# Altium schematic sheet LOAD_SWITCHES.SchDoc
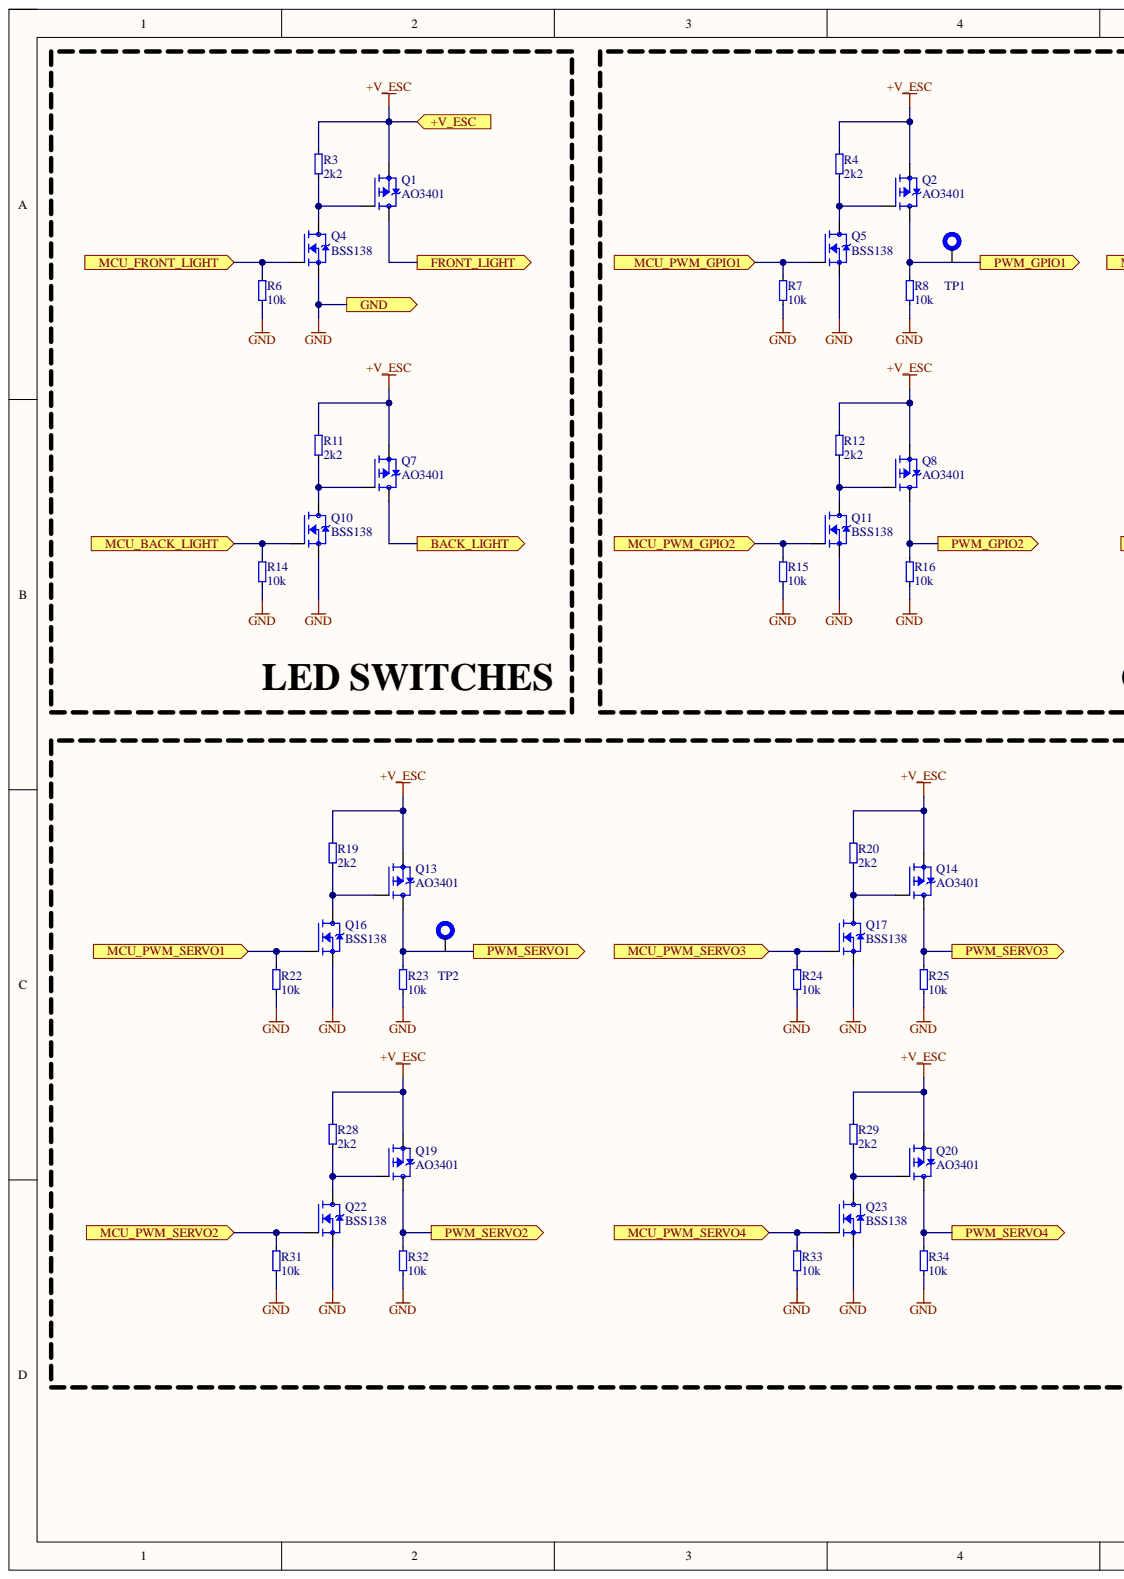
[diagram p0: LOAD_SWITCHES.SchDoc, left]
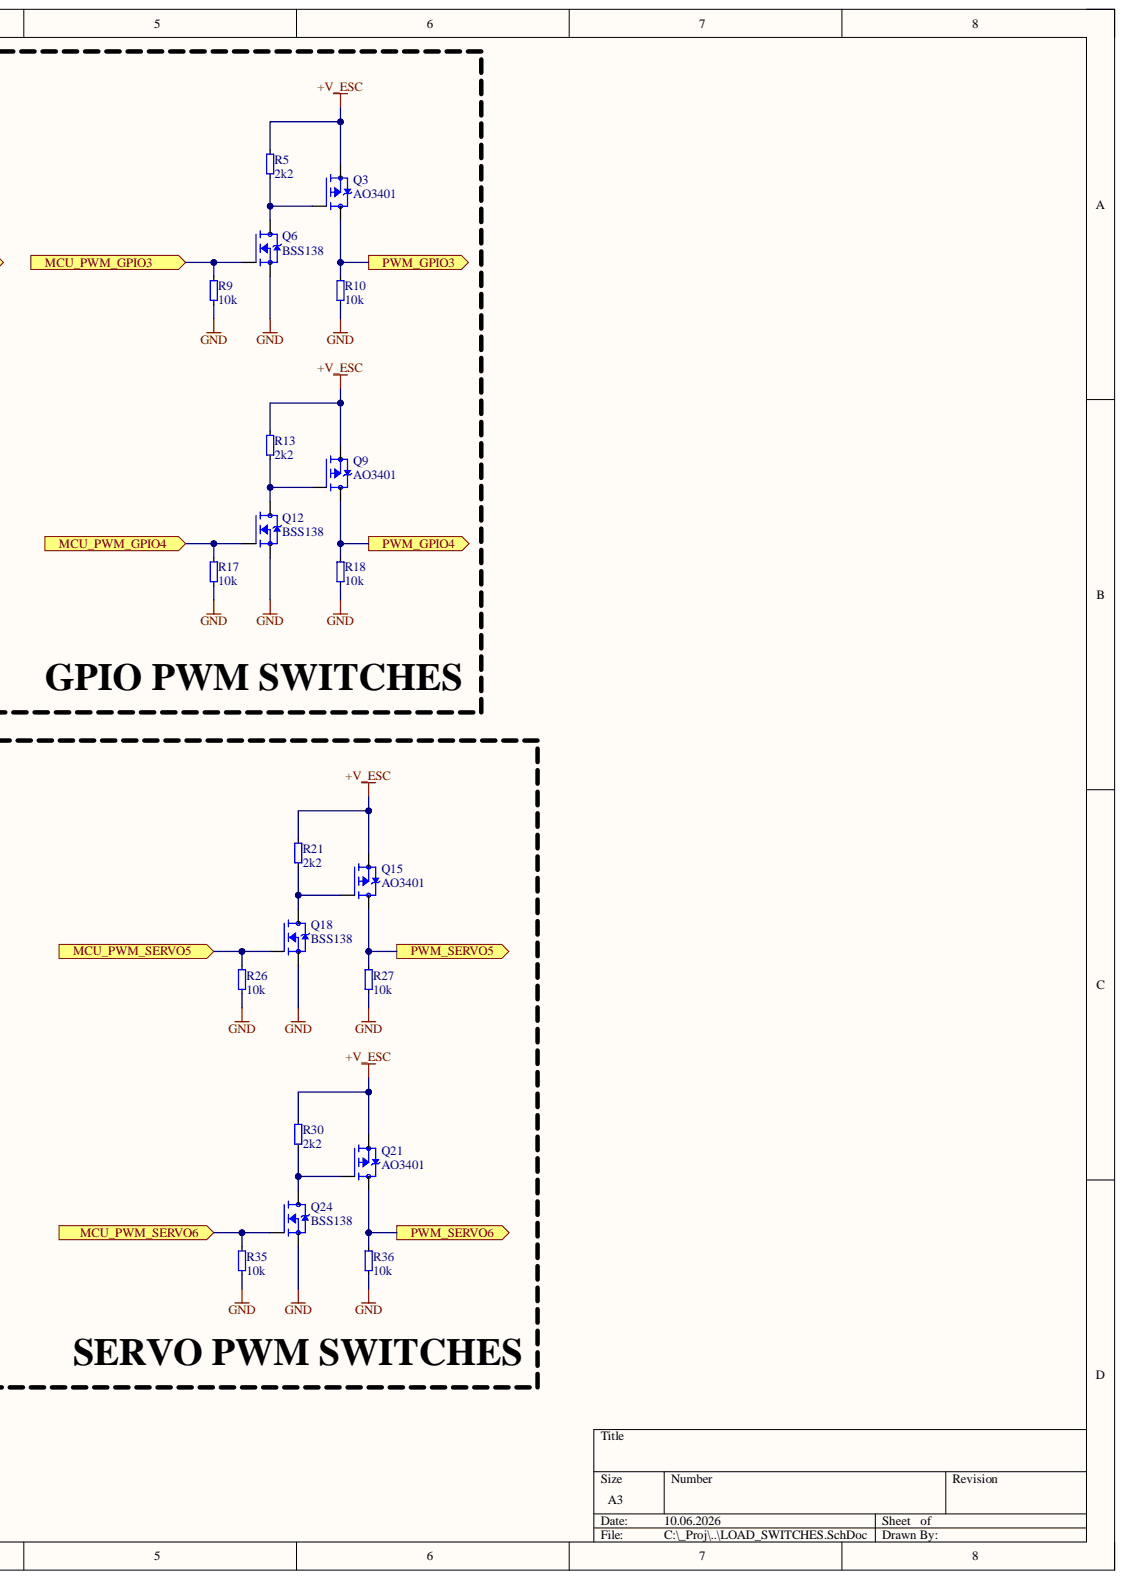
[diagram p1: LOAD_SWITCHES.SchDoc, right]

## Parts
Q1  AO3401  [SOT95P240X111-3N]
Q2  AO3401  [SOT95P240X111-3N]
Q3  AO3401  [SOT95P240X111-3N]
Q4  BSS138  [SOT95P240X111-3N]
Q5  BSS138  [SOT95P240X111-3N]
Q6  BSS138  [SOT95P240X111-3N]
Q7  AO3401  [SOT95P240X111-3N]
Q8  AO3401  [SOT95P240X111-3N]
Q9  AO3401  [SOT95P240X111-3N]
Q10  BSS138  [SOT95P240X111-3N]
Q11  BSS138  [SOT95P240X111-3N]
Q12  BSS138  [SOT95P240X111-3N]
Q13  AO3401  [SOT95P240X111-3N]
Q14  AO3401  [SOT95P240X111-3N]
Q15  AO3401  [SOT95P240X111-3N]
Q16  BSS138  [SOT95P240X111-3N]
Q17  BSS138  [SOT95P240X111-3N]
Q18  BSS138  [SOT95P240X111-3N]
Q19  AO3401  [SOT95P240X111-3N]
Q20  AO3401  [SOT95P240X111-3N]
Q21  AO3401  [SOT95P240X111-3N]
Q22  BSS138  [SOT95P240X111-3N]
Q23  BSS138  [SOT95P240X111-3N]
Q24  BSS138  [SOT95P240X111-3N]
R3  2k2  [R0402]
R4  2k2  [R0402]
R5  2k2  [R0402]
R6  10k  [R0402]
R7  10k  [R0402]
R8  10k  [R0402]
R9  10k  [R0402]
R10  10k  [R0402]
R11  2k2  [R0402]
R12  2k2  [R0402]
R13  2k2  [R0402]
R14  10k  [R0402]
R15  10k  [R0402]
R16  10k  [R0402]
R17  10k  [R0402]
R18  10k  [R0402]
R19  2k2  [R0402]
R20  2k2  [R0402]
R21  2k2  [R0402]
R22  10k  [R0402]
R23  10k  [R0402]
R24  10k  [R0402]
R25  10k  [R0402]
R26  10k  [R0402]
R27  10k  [R0402]
R28  2k2  [R0402]
R29  2k2  [R0402]
R30  2k2  [R0402]
R31  10k  [R0402]
R32  10k  [R0402]
R33  10k  [R0402]
R34  10k  [R0402]
R35  10k  [R0402]
R36  10k  [R0402]
TP1  TP  [TP]  (no BOM)
TP2  TP  [TP]  (no BOM)

## Ports
+V_ESC, BACK_LIGHT, FRONT_LIGHT, GND, MCU_BACK_LIGHT, MCU_FRONT_LIGHT, MCU_PWM_GPIO1, MCU_PWM_GPIO2, MCU_PWM_GPIO3, MCU_PWM_GPIO4, MCU_PWM_SERVO1, MCU_PWM_SERVO2, MCU_PWM_SERVO3, MCU_PWM_SERVO4, MCU_PWM_SERVO5, MCU_PWM_SERVO6, PWM_GPIO1, PWM_GPIO2, PWM_GPIO3, PWM_GPIO4, PWM_SERVO1, PWM_SERVO2, PWM_SERVO3, PWM_SERVO4, PWM_SERVO5, PWM_SERVO6

## Nets
+V_ESC: Q1.3 Q2.3 Q3.3 Q7.3 Q8.3 Q9.3 Q13.3 Q14.3 Q15.3 Q19.3 Q20.3 Q21.3 R3.2 R4.2 R5.2 R11.2 R12.2 R13.2 R19.2 R20.2 R21.2 R28.2 R29.2 R30.2 ; port +V_ESC
BACK_LIGHT: Q7.2 ; port BACK_LIGHT
FRONT_LIGHT: Q1.2 ; port FRONT_LIGHT
GND: Q4.2 Q5.2 Q6.2 Q10.2 Q11.2 Q12.2 Q16.2 Q17.2 Q18.2 Q22.2 Q23.2 Q24.2 R6.1 R7.1 R8.1 R9.1 R10.1 R14.1 R15.1 R16.1 R17.1 R18.1 R22.1 R23.1 R24.1 R25.1 R26.1 R27.1 R31.1 R32.1 R33.1 R34.1 R35.1 R36.1 ; port GND
MCU_BACK_LIGHT: Q10.1 R14.2 ; port MCU_BACK_LIGHT
MCU_FRONT_LIGHT: Q4.1 R6.2 ; port MCU_FRONT_LIGHT
MCU_PWM_GPIO1: Q5.1 R7.2 ; port MCU_PWM_GPIO1
MCU_PWM_GPIO2: Q11.1 R15.2 ; port MCU_PWM_GPIO2
MCU_PWM_GPIO3: Q6.1 R9.2 ; port MCU_PWM_GPIO3
MCU_PWM_GPIO4: Q12.1 R17.2 ; port MCU_PWM_GPIO4
MCU_PWM_SERVO1: Q16.1 R22.2 ; port MCU_PWM_SERVO1
MCU_PWM_SERVO2: Q22.1 R31.2 ; port MCU_PWM_SERVO2
MCU_PWM_SERVO3: Q17.1 R24.2 ; port MCU_PWM_SERVO3
MCU_PWM_SERVO4: Q23.1 R33.2 ; port MCU_PWM_SERVO4
MCU_PWM_SERVO5: Q18.1 R26.2 ; port MCU_PWM_SERVO5
MCU_PWM_SERVO6: Q24.1 R35.2 ; port MCU_PWM_SERVO6
PWM_GPIO1: Q2.2 R8.2 ; port PWM_GPIO1
PWM_GPIO2: Q8.2 R16.2 ; port PWM_GPIO2
PWM_GPIO3: Q3.2 R10.2 ; port PWM_GPIO3
PWM_GPIO4: Q9.2 R18.2 ; port PWM_GPIO4
PWM_SERVO1: Q13.2 R23.2 ; port PWM_SERVO1
PWM_SERVO2: Q19.2 R32.2 ; port PWM_SERVO2
PWM_SERVO3: Q14.2 R25.2 ; port PWM_SERVO3
PWM_SERVO4: Q20.2 R34.2 ; port PWM_SERVO4
PWM_SERVO5: Q15.2 R27.2 ; port PWM_SERVO5
PWM_SERVO6: Q21.2 R36.2 ; port PWM_SERVO6
NetQ1_1: Q1.1 Q4.3 R3.1
NetQ2_1: Q2.1 Q5.3 R4.1
NetQ3_1: Q3.1 Q6.3 R5.1
NetQ7_1: Q7.1 Q10.3 R11.1
NetQ8_1: Q8.1 Q11.3 R12.1
NetQ9_1: Q9.1 Q12.3 R13.1
NetQ13_1: Q13.1 Q16.3 R19.1
NetQ14_1: Q14.1 Q17.3 R20.1
NetQ15_1: Q15.1 Q18.3 R21.1
NetQ19_1: Q19.1 Q22.3 R28.1
NetQ20_1: Q20.1 Q23.3 R29.1
NetQ21_1: Q21.1 Q24.3 R30.1
NetTP1_1: TP1.1
NetTP2_1: TP2.1

## Library data of the parts
Q1-Q3 Q7-Q9 Q13-Q15 Q19-Q21 = AO3401: P-Channel 30 V 4A Surface Mount SOT-23-3; MF: onsemi; MP: BSS138; Check_prices: https://www.snapeda.com/parts/BSS138/Onsemi/view-part/?ref=eda; Component Kind: Standard; Package: SOT-23-3 ON Semiconductor; SnapEDA_Link: https://www.snapeda.com/parts/BSS138/Onsemi/view-part/?ref=snap; Library Name: Tractor2000.SchLib
Q4-Q6 Q10-Q12 Q16-Q18 Q22-Q24 = BSS138: N-Channel 50 V 220mA (Ta) 350mW (Ta) Surface Mount SOT-23-3; MF: onsemi; Check_prices: https://www.snapeda.com/parts/BSS138/Onsemi/view-part/?ref=eda; Component Kind: Standard; MP: BSS138; Package: SOT-23-3 ON Semiconductor; SnapEDA_Link: https://www.snapeda.com/parts/BSS138/Onsemi/view-part/?ref=snap; Library Name: Tractor2000.SchLib
R3-R36 = CMP-00016-11: Generic 0402 SMD Resistor; Component Kind: Standard; Library Name: PolSl Racing - Electrical
TP1 TP2 = TP: Library Name: Tractor2000.SchLib
